# Altium schematic sheet 3. I2C&UART&RS485.SchDoc
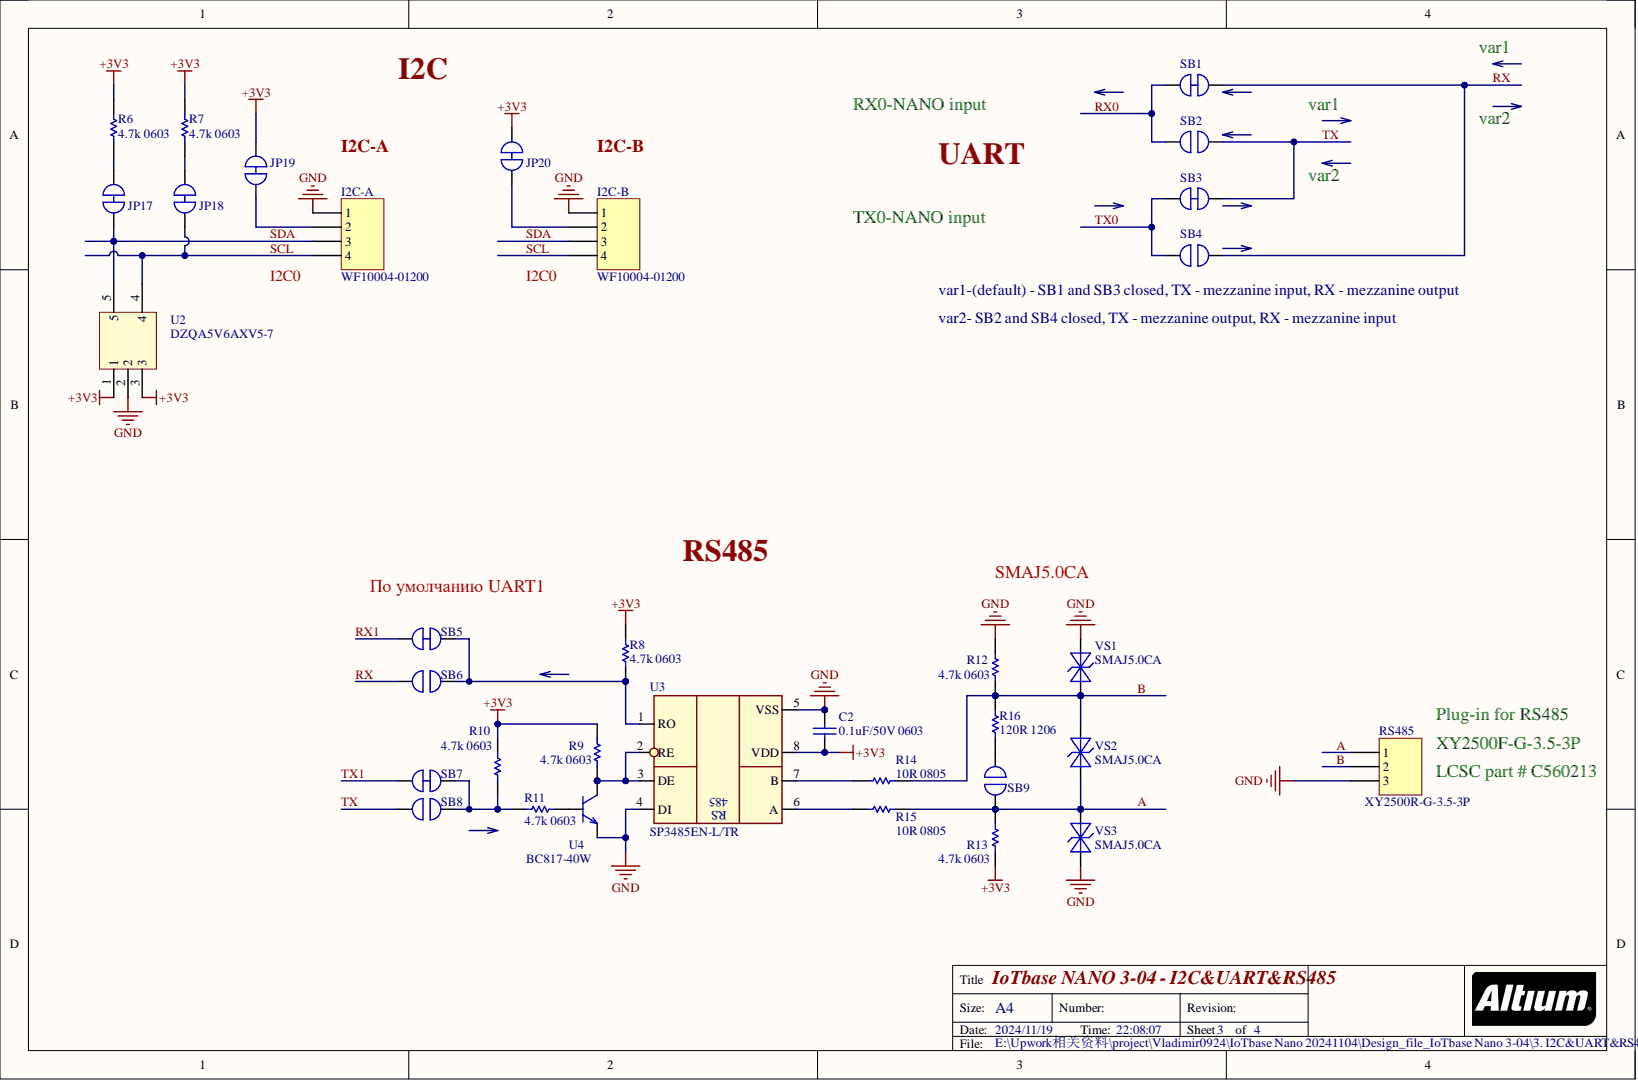
[diagram p0: 3. I2C&UART&RS485.SchDoc]

## Parts
C2  0.1uF/50V 0603  [CAPC1608X100N]
I2C-A  WF10004-01200  [SM04B-SRSS-TB(LF)(SN)]
I2C-B  WF10004-01200  [SM04B-SRSS-TB(LF)(SN)]
JP17  SOLDER_JUMPER_NC  [SOLDER_JUMPER_NC]  (value not drawn)  (no BOM)
JP18  SOLDER_JUMPER_NC  [SOLDER_JUMPER_NC]  (value not drawn)  (no BOM)
JP19  SOLDER_JUMPER_NC  [SOLDER_JUMPER_NC]  (value not drawn)  (no BOM)
JP20  SOLDER_JUMPER_NC  [SOLDER_JUMPER_NC]  (value not drawn)  (no BOM)
R6  4.7k 0603  [RESC1608X50N]
R7  4.7k 0603  [RESC1608X50N]
R8  4.7k 0603  [RESC1608X50N]
R9  4.7k 0603  [RESC1608X50N]
R10  4.7k 0603  [RESC1608X50N]
R11  4.7k 0603  [RESC1608X50N]
R12  4.7k 0603  [RESC1608X50N]
R13  4.7k 0603  [RESC1608X50N]
R14  10R 0805  [RES 0805_2012]
R15  10R 0805  [RES 0805_2012]
R16  120R 1206  [RESC3216X70N]
RS485  XY2500R-G-3.5-3P  Supplier 1: LCSC  [CON_1X03_3.5MM_MALE_RA]
SB1  SOLDER_JUMPER_NC  [SOLDER_JUMPER_NC]  (value not drawn)  (no BOM)
SB2  SOLDER_JUMPER_NO  [SOLDER_JUMPER_NO_2]  (value not drawn)  (no BOM)
SB3  SOLDER_JUMPER_NC  [SOLDER_JUMPER_NC]  (value not drawn)  (no BOM)
SB4  SOLDER_JUMPER_NO  [SOLDER_JUMPER_NO_2]  (value not drawn)  (no BOM)
SB5  SOLDER_JUMPER_NC  [SOLDER_JUMPER_NC]  (value not drawn)  (no BOM)
SB6  SOLDER_JUMPER_NO  [SOLDER_JUMPER_NO_2]  (value not drawn)  (no BOM)
SB7  SOLDER_JUMPER_NC  [SOLDER_JUMPER_NC]  (value not drawn)  (no BOM)
SB8  SOLDER_JUMPER_NO  [SOLDER_JUMPER_NO_2]  (value not drawn)  (no BOM)
SB9  SOLDER_JUMPER_NO  [SOLDER_JUMPER_NO_2]  (value not drawn)  (no BOM)
U2  DZQA5V6AXV5-7  [SOT50P160X60-5N]
U3  SP3485EN-L/TR  [SO8]
U4  BC817-40W  [SOT65P222X110-3N]
VS1  SMAJ5.0CA  [SMAJ_BI]
VS2  SMAJ5.0CA  [SMAJ_BI]
VS3  SMAJ5.0CA  [SMAJ_BI]

## Nets
+3V3: C2.1 JP19.1 JP20.1 R6.1 R7.1 R8.1 R9.1 R10.1 R13.1 U2.1 U2.3 U3.8(VDD)
GND: C2.2 I2C-A.1 I2C-B.1 R12.1 RS485.3 U2.2 U3.4(DI) U3.5(VSS) U4.2 VS1.2 VS3.1
A: R13.2 R15.2 RS485.1 SB9.1 VS2.1 VS3.2
B: R12.2 R14.2 R16.2 RS485.2 VS1.1 VS2.2
RX: SB1.2 SB4.2 SB6.1
RX0: SB1.1 SB2.1
RX1: SB5.1
SCL: I2C-A.4 I2C-B.4 JP18.2 U2.4
SDA: I2C-A.3 I2C-B.3 JP17.2 U2.5
TX: SB2.2 SB3.2 SB8.1
TX0: SB3.1 SB4.1
TX1: SB7.1
NetI2C-A_2: I2C-A.2 JP19.2
NetI2C-B_2: I2C-B.2 JP20.2
NetJP17_1: JP17.1 R6.2
NetJP18_1: JP18.1 R7.2
NetR8_2: R8.2 SB5.2 SB6.2 U3.1(RO)
NetR9_2: R9.2 U3.2(RE) U3.3(DE) U4.3
NetR10_2: R10.2 R11.1 SB7.2 SB8.2
NetR11_2: R11.2 U4.1
NetR14_1: R14.1 U3.7(B)
NetR15_1: R15.1 U3.6(A)
NetR16_1: R16.1 SB9.2

## Library data of the parts
C2 = Cap: 50V 100nF X7R ±10% 0603 Multilayer Ceramic Capacitors MLCC - SMD/SMT ROHS; Manufacturer: Walsin Tech Corp; Manufacturer Part Number: 0603B104K500CT; Component Kind: Standard; Library Name: Miscellaneous Devices.IntLib; Signal Integrity: Cap; Simulation: CAP; Supplier 1: LCSC; Supplier Part Number 1: C314282; Value: 0.1uF/50V 0603
I2C-A = Header 4: Header, 4-Pin; Manufacturer: SHENZHEN ATOM TECH; Component Kind: Standard; Library Name: Miscellaneous Connectors.IntLib; Manufacturer Part Number: WF10004-01200; Signal Integrity: Connector; Supplier 1: LCSC; Supplier Part Number 1: C479990
I2C-B = Header 4: 1x4P 1 1mm Male pin 4 -25℃~+85℃ 500mA Surface Mount SMD,P=1mm Wire To Board / Wire To Wire Connector ROHS; Manufacturer: SHENZHEN ATOM TECH; Component Kind: Standard; Library Name: Miscellaneous Connectors.IntLib; Manufacturer Part Number: WF10004-01200; Signal Integrity: Connector; Supplier 1: LCSC; Supplier Part Number 1: C479990
JP17-JP20 SB1 SB3 SB5 SB7 = SOLDER_JUMPER_NC: Solder Jumper; Library Name: Schematic_Lib.SchLib
R6-R13 = Res1: 100mW Thick Film Resistors ±100ppm/℃ ±1% 4.7kΩ 0603 Chip Resistor - Surface Mount ROHS; Manufacturer: UNI-ROYAL(Uniroyal Elec); Manufacturer Part Number: 0603WAF4701T5E; Component Kind: Standard; Library Name: Miscellaneous Devices.IntLib; Supplier 1: LCSC; Supplier Part Number 1: C23162; Value: 4.7k 0603
R14 R15 = Res1: 125mW Thick Film Resistors ±1% ±200ppm/℃ 10Ω 0805 Chip Resistor - Surface Mount ROHS; Manufacturer: UNI-ROYAL(Uniroyal Elec); Manufacturer Part Number: 0805W8F100JT5E; Component Kind: Standard; Library Name: Miscellaneous Devices.IntLib; Supplier 1: LCSC; Supplier Part Number 1: C17415; Value: 10R 0805
R16 = Res1: 100mW Thick Film Resistors ±100ppm/℃ ±1% 120Ω 1206 Chip Resistor - Surface Mount ROHS JLSC #C114928; Manufacturer: UNI-ROYAL(Uniroyal Elec); Manufacturer Part Number: 0603WAF1200T5E; Component Kind: Standard; Library Name: Miscellaneous Devices.IntLib; Supplier 1: LCSC; Supplier Part Number 1: C114928; Value: 120R 1206
RS485 = Header 3: 300V 8A 3 Bend 1 3.5mm 1x3P Green Board Edge/Receptacle-Close Push-Pull,P=3.5mm Pluggable System Terminal Block ROHS; Manufacturer: Ningbo Xinlaiya Elec.; Component Kind: Standard; Library Name: Miscellaneous Connectors.IntLib; Manufacturer Part Number: XY2500R-G-3.5-3P; Signal Integrity: Connector; Supplier Part Number 1: C880573; Value: XY2500R-G-3.5-3P
SB2 SB4 SB6 SB8 SB9 = SOLDER_JUMPER_NO: Solder Jumper; Library Name: Schematic_Lib.SchLib
U2 = NZQA5V6XV5T1G: 5.3V 13V 4 3V SOT-553 ESD Protection Devices ROHS; Manufacturer: Diodes Incorporated; Component Kind: Standard; Manufacturer Part Number: DZQA5V6AXV5-7; Supplier 1: LCSC; Supplier Part Number 1: C1973056; Library Name: IoTbase B+ 3-01.SCHLIB
U3 = ST1480ABDR: transceiver 10Mbps 1/1 SOP-8 RS-485/RS-422 ICs ROHS; Manufacturer: MaxLinear; Component Kind: Standard; Library Name: BTGV6_0814.SchLib; Manufacturer Part Number: SP3485EN-L/TR; Supplier 1: LCSC; Supplier Part Number 1: C8963
U4 = BC817-40: 45V 200mW 250@100mA,1V 500mA NPN SOT-323(SC-70) Bipolar Transistors - BJT ROHS; Manufacturer: Slkor(SLKORMICRO Elec.); Component Kind: Standard; Library Name: BTGV6_0814.SchLib; Manufacturer Part Number: BC817-40W; Supplier 1: LCSC; Supplier Part Number 1: C2936046; Value: BC817-40W
VS1-VS3 = SMAJxxCA: 9.2V 6.4V 5V SMA(DO-214AC) TVS ROHS; Manufacturer: GOODWORK; Component Kind: Standard; Manufacturer Part Number: SMAJ5.0CA; PartNumber1: SMAJ5.0CA; Supplier 1: LCSC; Supplier Part Number 1: C908809; Value: SMAJ5.0CA; Library Name: IoTextra_Input_2-03.SCHLIB
